# Altium schematic sheet NIRpixel.SchDoc(NIRpixel)
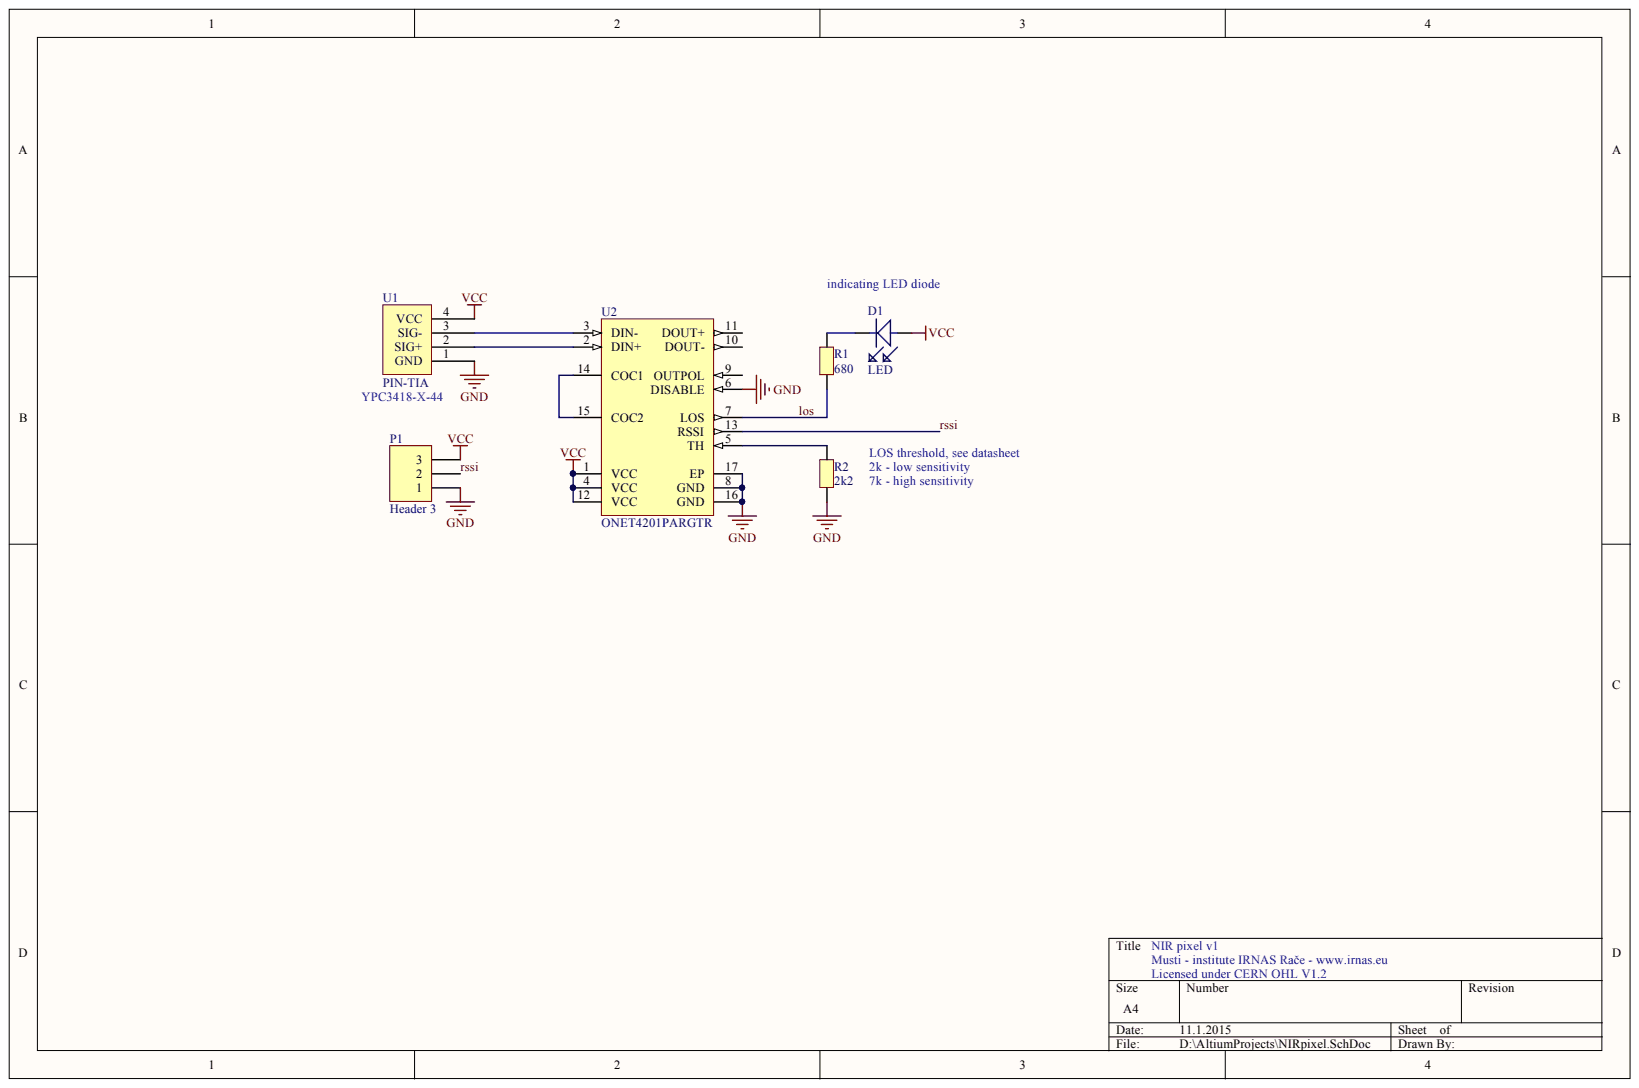
[diagram p0: NIRpixel.SchDoc]

## Parts
D1  LED  [0603]
P1  Header 3  [HDR1X3]
R1  680  [RESC0402(1005)_M]
R2  2k2  [RESC0402(1005)_M]
U1  PIN-TIA  [TO-46-4-HEX]
U2  ONET4201PARGTR  [TI-RGT16-1450X1450TP]

## Nets
GND: P1.1 R2.1 U1.1(GND) U2.6(DISABLE) U2.8(GND) U2.16(GND) U2.17(EP)
VCC: D1.1 P1.3 U1.4(VCC) U2.1(VCC) U2.4(VCC) U2.12(VCC)
LOS: R1.1 U2.7(LOS)
RSSI: P1.2 U2.13(RSSI)
NetD1_2: D1.2 R1.2
NetR2_2: R2.2 U2.5(TH)
NetU1_2: U1.2(SIG+) U2.2(DIN+)
NetU1_3: U1.3(SIG-) U2.3(DIN-)
NetU2_14: U2.14(COC1) U2.15(COC2)

Not connected: U2 9(OUTPOL) 10(DOUT-) 11(DOUT+)

## Library data of the parts
D1 = LED: LED diode; Component Kind: Standard; Library Name: GeneralComponents.SchLib
P1 = Header 3: Header, 3-Pin; Component Kind: Standard; LatestRevisionDate: 17-Jul-2002; LatestRevisionNote: Re-released for DXP Platform.; Library Name: Miscellaneous Connectors.IntLib; Signal Integrity: Connector; Publisher: Altium Limited
R1 R2 = Resistor: Resistor 0805 smd; Component Kind: Standard; Library Name: GeneralComponents.SchLib
U1 = PIN-TIA: PIN TIA photodiode; Component Kind: Standard; Library Name: GeneralComponents.SchLib
U2 = CMP-0300-00008-1: 155 Mbps to 4.25 Gbps Limiting Amplifier with LOS and RSSI, 50dB, -40 to 85 degC, 16-Pin QFN (RGT), Green (RoHS & no Sb/Br); Data Rate(Typ)(Gbps): 0.155 - 4.25; DJ(Typ)(ps): 3; Gain(Typ)(dB): 50; ICC(Max)(mA): 45; ICC(Nom)(mA): 27; RJ(Max)(ps): 4; VCC(V): 3.3; Vin Max(Min)(mV p-p): 1200; Vin Min(Nom)(mV p-p): 5; Component Kind: Standard; ComponentLink1Description: Manufacturer URL; ComponentLink1URL: www.ti.com; ComponentLink2Description: Datasheet; ComponentLink2URL: http://www.ti.com/general/docs/lit/getliterature.tsp?genericPartNumber=ONET4201PA&fileType=pdf; ComponentLink3Description: Package Specification; ComponentLink3URL: http://www.ti.com/litv/pdf/mpqf119g; DatasheetVersion: SLLS652; Library Name: Altium Content Vault; PackageDescription: 16-Pin Plastic Quad Flat No-Lead, 3 x 3 mm Body, 0.5 mm Pitch, 1.45 x 1.45 mm Exposed Pad; PackageReference: RGT16-1450X1450TP; PackageVersion: revH, Oct-2011; SubFamily: Limiting Amplifier; tf(Typ)(ps): 45; tr(Typ)(ps): 45
